# Altium schematic sheet ACTIVATOR_RESISTOR.SchDoc
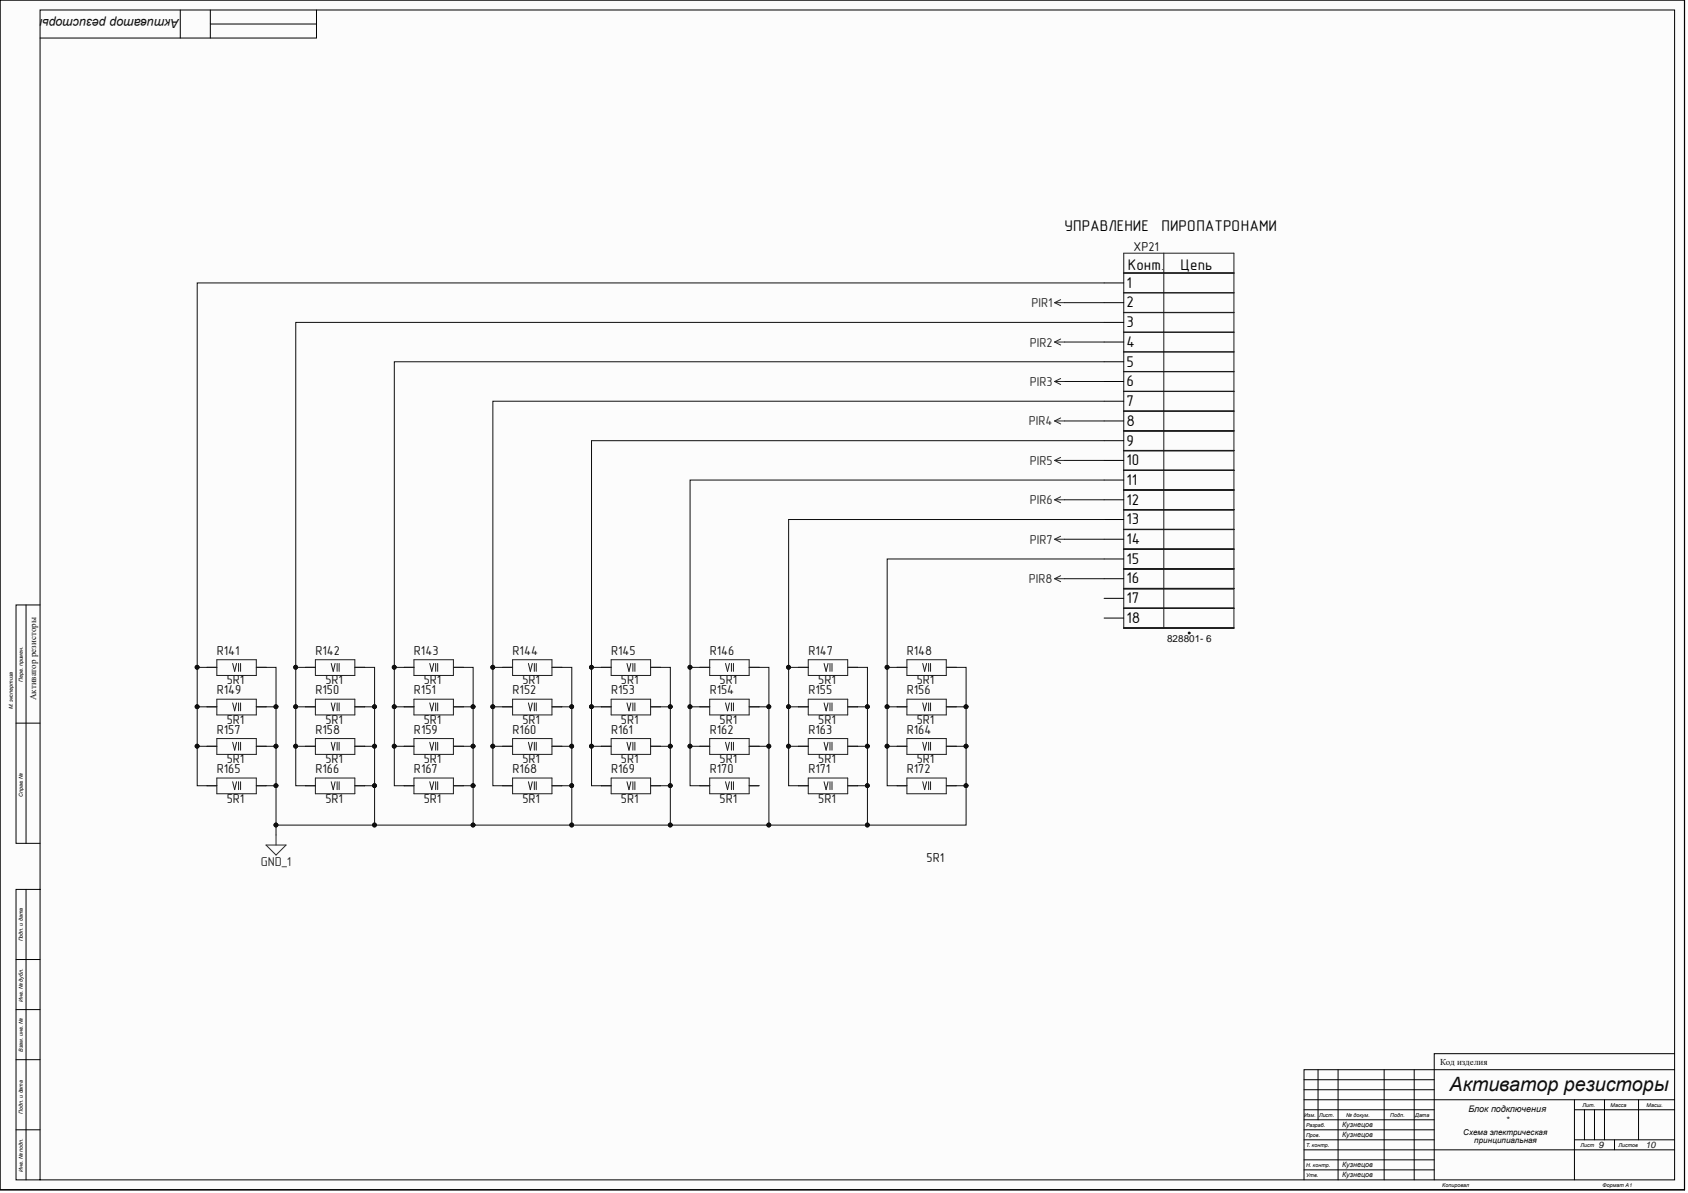
[diagram p0: ACTIVATOR_RESISTOR.SchDoc]

## Parts
R141  value 5R1  [R7W Vertical]  (hidden comment: CPCC07)
R142  value 5R1  [R7W Vertical]  (hidden comment: CPCC07)
R143  value 5R1  [R7W Vertical]  (hidden comment: CPCC07)
R144  value 5R1  [R7W Vertical]  (hidden comment: CPCC07)
R145  value 5R1  [R7W Vertical]  (hidden comment: CPCC07)
R146  value 5R1  [R7W Vertical]  (hidden comment: CPCC07)
R147  value 5R1  [R7W Vertical]  (hidden comment: CPCC07)
R148  value 5R1  [R7W Vertical]  (hidden comment: CPCC07)
R149  value 5R1  [R7W Vertical]  (hidden comment: CPCC07)
R150  value 5R1  [R7W Vertical]  (hidden comment: CPCC07)
R151  value 5R1  [R7W Vertical]  (hidden comment: CPCC07)
R152  value 5R1  [R7W Vertical]  (hidden comment: CPCC07)
R153  value 5R1  [R7W Vertical]  (hidden comment: CPCC07)
R154  value 5R1  [R7W Vertical]  (hidden comment: CPCC07)
R155  value 5R1  [R7W Vertical]  (hidden comment: CPCC07)
R156  value 5R1  [R7W Vertical]  (hidden comment: CPCC07)
R157  value 5R1  [R7W Vertical]  (hidden comment: CPCC07)
R158  value 5R1  [R7W Vertical]  (hidden comment: CPCC07)
R159  value 5R1  [R7W Vertical]  (hidden comment: CPCC07)
R160  value 5R1  [R7W Vertical]  (hidden comment: CPCC07)
R161  value 5R1  [R7W Vertical]  (hidden comment: CPCC07)
R162  value 5R1  [R7W Vertical]  (hidden comment: CPCC07)
R163  value 5R1  [R7W Vertical]  (hidden comment: CPCC07)
R164  value 5R1  [R7W Vertical]  (hidden comment: CPCC07)
R165  value 5R1  [R7W Vertical]  (hidden comment: CPCC07)
R166  value 5R1  [R7W Vertical]  (hidden comment: CPCC07)
R167  value 5R1  [R7W Vertical]  (hidden comment: CPCC07)
R168  value 5R1  [R7W Vertical]  (hidden comment: CPCC07)
R169  value 5R1  [R7W Vertical]  (hidden comment: CPCC07)
R170  value 5R1  [R7W Vertical]  (hidden comment: CPCC07)
R171  value 5R1  [R7W Vertical]  (hidden comment: CPCC07)
R172  value 5R1  [R7W Vertical]  (hidden comment: CPCC07)
XP21  828801- 6  [828801- 6]

## Nets
GND_1: R141.2 R142.2 R143.2 R144.2 R145.2 R146.2 R147.2 R148.2 R149.2 R150.2 R151.2 R152.2 R153.2 R154.2 R155.2 R156.2 R157.2 R158.2 R159.2 R160.2 R161.2 R162.2 R163.2 R164.2 R165.2 R166.2 R167.2 R168.2 R169.2 R171.2 R172.2
PIR1: XP21.2
PIR2: XP21.4
PIR3: XP21.6
PIR4: XP21.8
PIR5: XP21.10
PIR6: XP21.12
PIR7: XP21.14
PIR8: XP21.16
NetR141_1: R141.1 R149.1 R157.1 R165.1 XP21.1
NetR142_1: R142.1 R150.1 R158.1 R166.1 XP21.3
NetR143_1: R143.1 R151.1 R159.1 R167.1 XP21.5
NetR144_1: R144.1 R152.1 R160.1 R168.1 XP21.7
NetR145_1: R145.1 R153.1 R161.1 R169.1 XP21.9
NetR146_1: R146.1 R154.1 R162.1 R170.1 XP21.11
NetR147_1: R147.1 R155.1 R163.1 R171.1 XP21.13
NetR148_1: R148.1 R156.1 R164.1 R172.1 XP21.15

Not connected: R170 2; XP21 17 18

## Library data of the parts
R141-R172 = CPCC07: Импортный постоянный углеродистый резистор; Manufacturer: Vishay Dale; Power\Voltage: 7W; TKE: ±400ppm/°C; Tolerance: 5%; Корпус: Axial; Примечание: CPCC075R100JE66; Component Kind: Standard; ComponentLink1Description: Параметры; ComponentLink2Description: Сайт производителя; ManufacturerPartNumber: CPCC07; Author: Eleman; ComponentLink1URL: d:\YandexDisk\Project_Altium\Lib_Eleman\; Library Name: Eleman_Resistor.SchLib
XP21 = 828801- 6: Разъем; Manufacturer: TE Connectivity AMP Connectors; Корпус: 18 pin; Component Kind: Standard; ComponentLink1Description: Параметры; ComponentLink2Description: Сайт производителя; ManufacturerPartNumber: 828801- 6; Author: Eleman; ComponentLink1URL: C:\Demo\Datasheet; Library Name: Eleman_Connector.SchLib
